# Altium schematic sheet CGI-300_v1.0.0_MAIN.SchDoc(CGI-300_v1.0.0_MAIN)
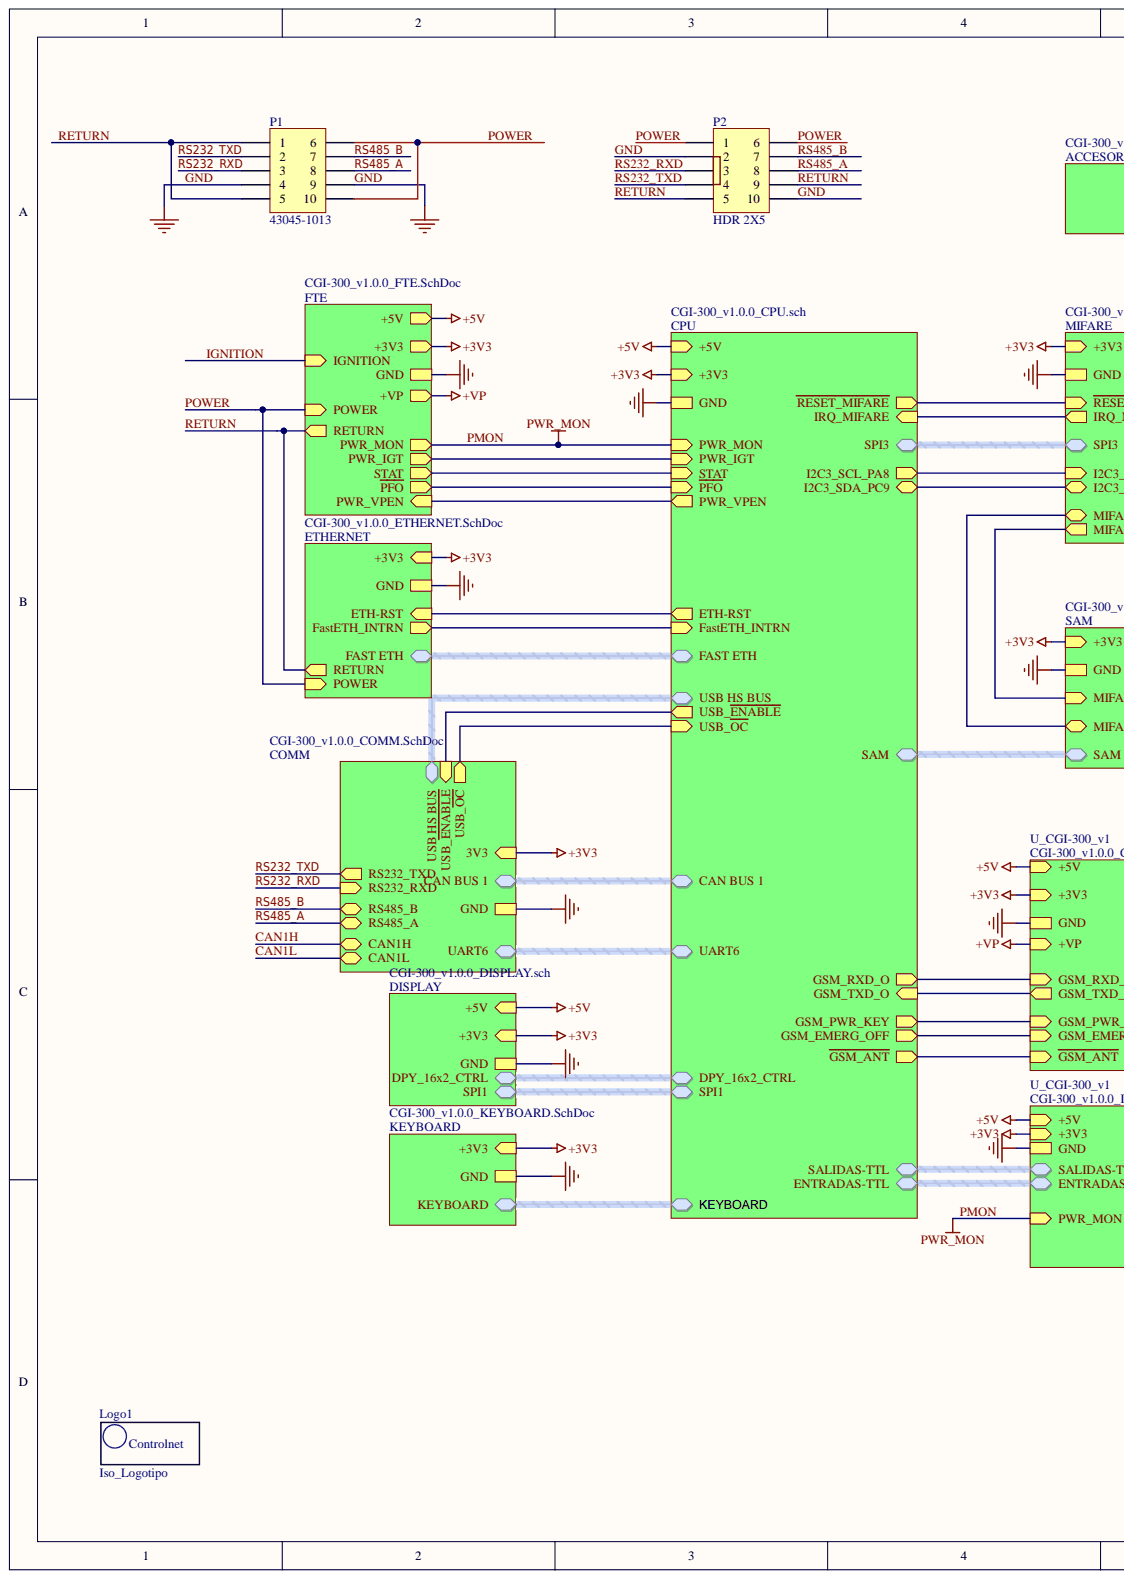
[diagram p0: CGI-300_v1.0.0_MAIN.SchDoc, left]
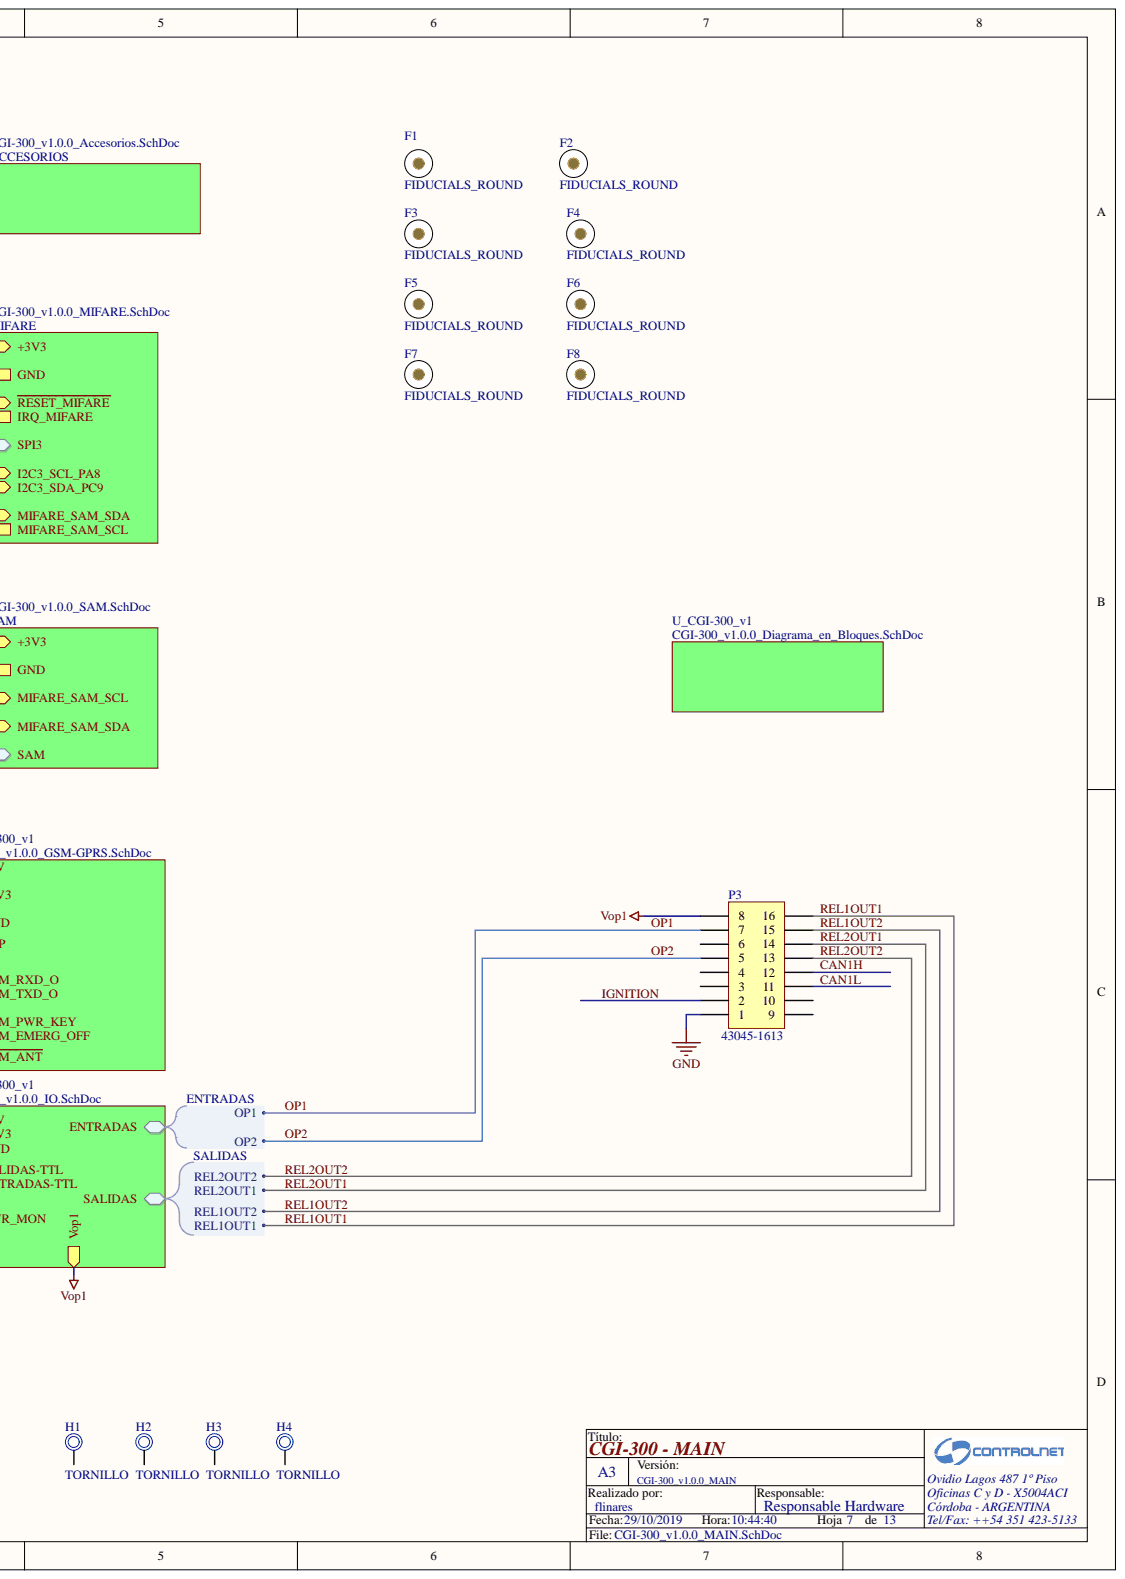
[diagram p1: CGI-300_v1.0.0_MAIN.SchDoc, right]

## Parts
F1  FIDUCIALS_ROUND  [FIDUCIALS_ROUND]
F2  FIDUCIALS_ROUND  [FIDUCIALS_ROUND]
F3  FIDUCIALS_ROUND  [FIDUCIALS_ROUND]
F4  FIDUCIALS_ROUND  [FIDUCIALS_ROUND]
F5  FIDUCIALS_ROUND  [FIDUCIALS_ROUND]
F6  FIDUCIALS_ROUND  [FIDUCIALS_ROUND]
F7  FIDUCIALS_ROUND  [FIDUCIALS_ROUND]
F8  FIDUCIALS_ROUND  [FIDUCIALS_ROUND]
H1  TORNILLO  [Tornillo]
H2  TORNILLO  [Tornillo]
H3  TORNILLO  [Tornillo]
H4  TORNILLO  [Tornillo]
Logo1  Iso_Logotipo  ManufacturerName: Controlnet  [New_Full_100_02]
P1  Header 5X2A  [MICROFIT-2X5-VERTICAL]  (value not drawn)
P2  HDR 2X5  [FCI 72454-010LF]
P3  Header 8X2A  [MICROFIT-2X8-VERTICAL]  (value not drawn)

## Nets
Vop1: P3.8
CAN1H: P3.12
CAN1L: P3.11
GND: P1.4 P1.9 P2.2 P2.10 P3.1
IGNITION: P3.2
OP1: P3.7
OP2: P3.5
POWER: P1.6 P1.10 P2.1 P2.6
REL1OUT1: P3.16
REL1OUT2: P3.15
REL2OUT1: P3.14
REL2OUT2: P3.13
RETURN: P1.1 P1.5 P2.5 P2.9
RS232_RXD: P1.3 P2.3
RS232_TXD: P1.2 P2.4
RS485_A: P1.8 P2.8
RS485_B: P1.7 P2.7
NetH1_1: H1.1
NetH2_1: H2.1
NetH3_1: H3.1
NetH4_1: H4.1
NetP3_3: P3.3
NetP3_4: P3.4
NetP3_6: P3.6
NetP3_9: P3.9
NetP3_10: P3.10
+3V3:
+5V:
+VP:
CAN BUS 1.CANRX1:
CAN BUS 1.CANTX1:
DPY_16x2_CTRL.DISPLAY-BL_CTRL:
DPY_16x2_CTRL.DPY_~{CTR}:
DPY_16x2_CTRL.DPY_RST:
ENTRADAS-TTL.TTL-IN1:
ENTRADAS-TTL.TTL-IN2:
ETH-RST:
FAST ETH.MDC:
FAST ETH.MDIO:
FAST ETH.RMII_CRS_DV:
FAST ETH.RMII_REF_CLK:
FAST ETH.RMII_RXD0:
FAST ETH.RMII_RXD1:
FAST ETH.RMII_TX_EN:
FAST ETH.RMII_TXD0:
FAST ETH.RMII_TXD1:
FastETH_INTRN:
~{GSM_ANT}:
GSM_EMERG_OFF:
GSM_PWR_KEY:
GSM_RXD_O:
GSM_TXD_O:
I2C3_SCL_PA8:
I2C3_SDA_PC9:
IRQ_MIFARE:
KEYBOARD.KEY_I2C1_SCL_PB6:
KEYBOARD.KEY_I2C1_SDA_PB7:
KEYBOARD.KEY_INIT:
KEYBOARD.KEY_RESET:
MIFARE_SAM_SCL:
MIFARE_SAM_SDA:
~{PFO}:
PWR_IGT:
PWR_MON:
PWR_VPEN:
~{RESET_MIFARE}:
SALIDAS-TTL.TTL-OUT-1:
SALIDAS-TTL.TTL-OUT-2:
SAM.#IRQ_SAM:
SAM.I2C3_SCL_PA8:
SAM.I2C3_SDA_PC9:
SAM.SAM_CARD_CLK:
SAM.SAM_CARD_I/O:
SPI1.SPI1_CLK:
SPI1.SPI1_CS0:
SPI1.SPI1_MISO:
SPI1.SPI1_MOSI:
SPI3.SPI3_CLK:
SPI3.SPI3_CS:
SPI3.SPI3_MDI:
SPI3.SPI3_MDO:
STAT:
UART6.RS485_DIR_1:
UART6.U6_RXD:
UART6.U6_TXD:
UART6.USART1_RXD:
UART6.USART1_TXD:
USB HS BUS.USB_HS_N:
USB HS BUS.USB_HS_P:
USB_~{ENABLE}:
USB_~{OC}:

## Library data of the parts
F1-F8 = FIDUCIALS_ROUND: ManufacturerName: Controlnet; SortOrder: 13; mauto: 1; Database Table Name: detalles_pcb; CPN: PCB13; Value: FIDUCIALS; Part Number: FIDUCIALS_ROUND; Descripcion: FIDUCIALS; Component Kind: Standard; Library Name: BD_2015_Win7.DbLib
H1-H4 = TORNILLO: ManufacturerName: Controlnet; Value: M3-1/8; SortOrder: 2; mauto: 0; Descripcion: Indicación de Screw Hole no part number; Database Table Name: detalles_pcb; CPN: PCB2; Part Number: TORNILLO; Library Name: Database_Libs_BASE_ALTIUM.DbLib; ENBOM: 0; Description: Tornillo; Component Kind: Standard
Logo1 = ISOLOGO: SortOrder: 7; mauto: 0; Descripcion: Indicacion de ISOLOGO no part number; Database Table Name: detalles_pcb; CPN: PCB7; Value: ISOLOGO; Part Number: ISOLOGO; Library Name: Database_Libs_BASE_ALTIUM.DbLib; ENBOM: 0; Component Kind: Standard
P1 = 43045-1013: Header, 5-Pin, Dual row; ManufacturerName: Molex Connector Corporation; Value: CONN HEADER 10POS 3MM VERT GOLD; SortOrder: 36; mauto: 0; Foto: Y:\fotos\43045-1013.jpg; Encapsulado: 0.118" (3.00mm); ENBOM: 1; Database Table Name: conectores; CPN: CON36; Signal Integrity: Connector; RefSupplierPartNumber: WM2652-ND; RefSupplierName: Digikey; Part Number: 43045-1013; Package: Tray; Library Name: Database_Libs_BASE_ALTIUM.DbLib; LatestRevisionNote: Re-released for DXP Platform.; LatestRevisionDate: 17-Jul-2002; Descripcion: CONN HEADER 10POS 3MM VERT GOLD; Component Kind: Standard; Publisher: Altium Limited
P2 = FCI 72454-010LF: ManufacturerName: FCI; Value: CONN HEADER 10POS SMD VERT 30AU; SortOrder: 89; mauto: 0; Encapsulado: Through Hole, Vertical; ENBOM: 1; Database Table Name: conectores; CPN: CON89; RefSupplierPartNumber: 609-3075-ND; RefSupplierName: Digikey; Part Number: FCI 72454-010LF; Package: Tray; Library Name: Database_Libs_BASE_ALTIUM.DbLib; Descripcion: CONN HEADER 10POS SMD VERT 30AU; Component Kind: Standard
P3 = 43045-1613: Header, 8-Pin, Dual row; ManufacturerName: Molex Connector Corporation; Value: CONN HEADER 16POS 3MM VERT GOLD; SortOrder: 4; mauto: 0; Foto: Y:\fotos\43045-1613.jpg; Encapsulado: 0.118" (3.00mm); ENBOM: 1; Database Table Name: conectores; CPN: CON4; Signal Integrity: Connector; RefSupplierPartNumber: WM2655-ND; RefSupplierName: Digikey; Part Number: 43045-1613; Package: Tray; Library Name: Database_Libs_BASE_ALTIUM.DbLib; LatestRevisionNote: Re-released for DXP Platform.; LatestRevisionDate: 17-Jul-2002; Descripcion: CONN HEADER 16POS 3MM VERT GOLD; Component Kind: Standard; Publisher: Altium Limited
